# Altium schematic sheet 02-RCB_Header.SchDoc(02-RCB_Header)
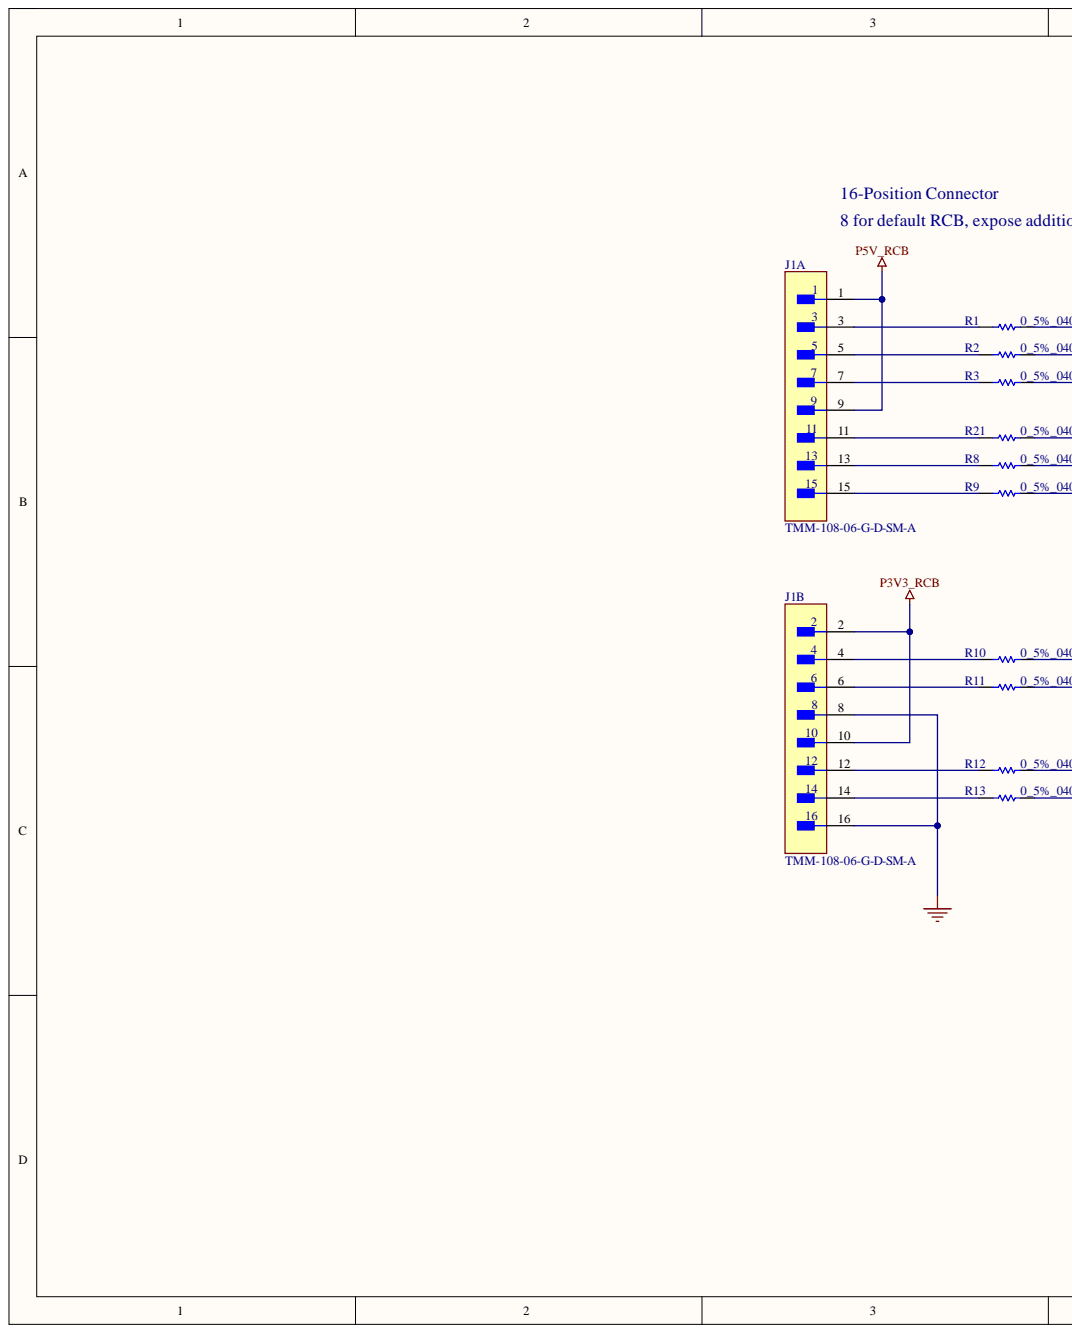
[diagram p0: 02-RCB_Header.SchDoc, left]
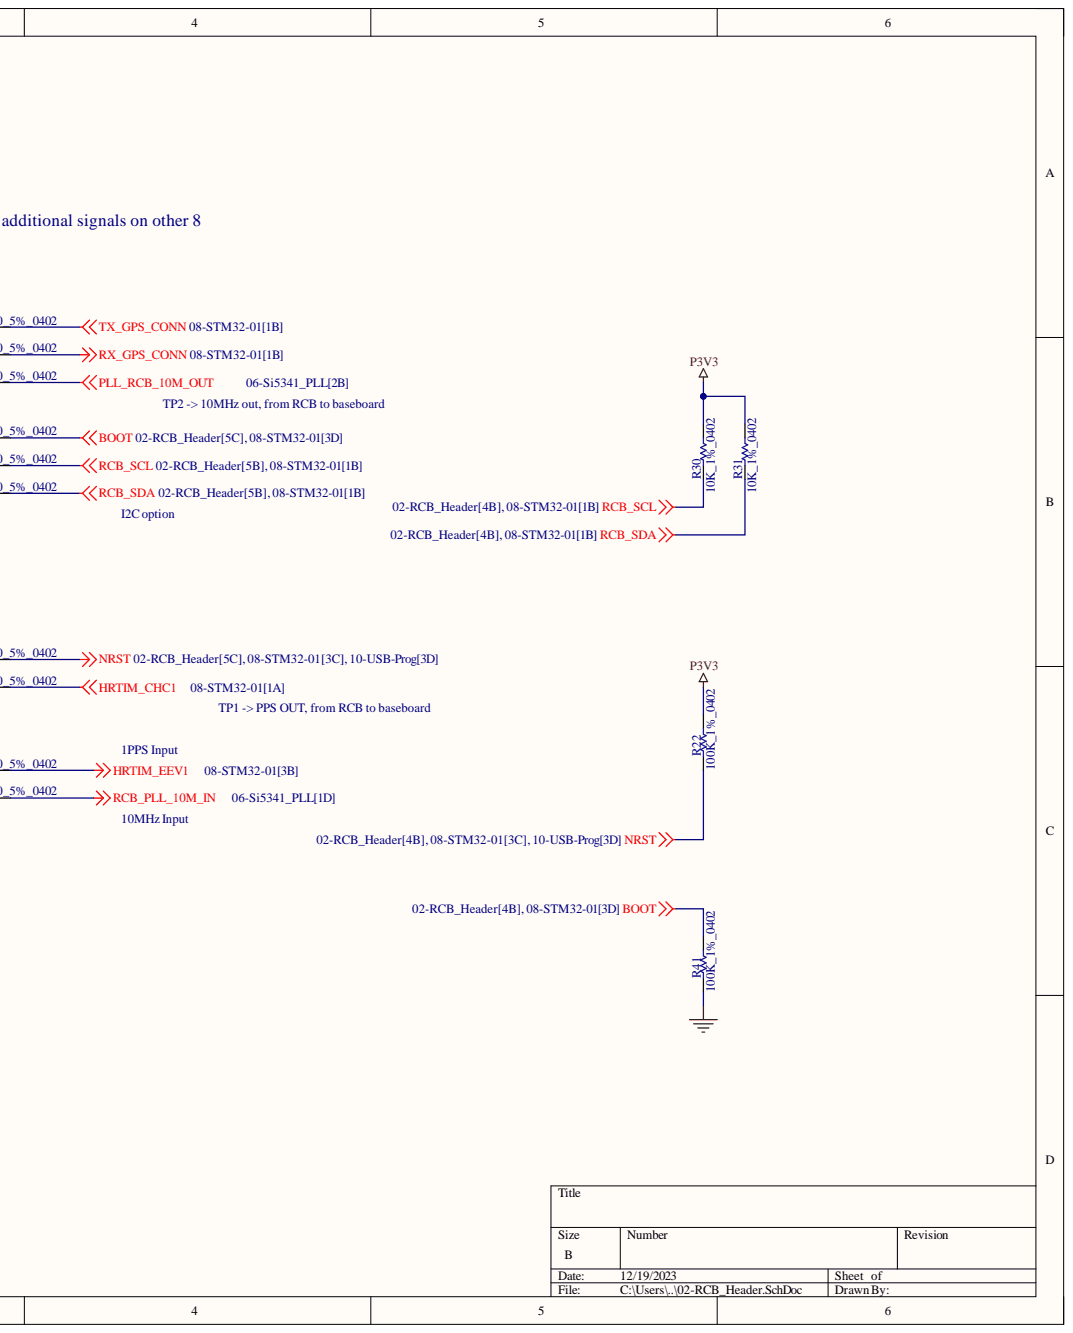
[diagram p1: 02-RCB_Header.SchDoc, right]

## Parts
J1  TMM-108-06-G-D-SM-A  [FP-TMM-108-06-G-D-SM-A-MFG]  (parts A,B)
R1  0_5%_0402  [RESC1005X40X25NL05T10]
R2  0_5%_0402  [RESC1005X40X25NL05T10]
R3  0_5%_0402  [RESC1005X40X25NL05T10]
R8  0_5%_0402  [RESC1005X40X25NL05T10]
R9  0_5%_0402  [RESC1005X40X25NL05T10]
R10  0_5%_0402  [RESC1005X40X25NL05T10]
R11  0_5%_0402  [RESC1005X40X25NL05T10]
R12  0_5%_0402  [RESC1005X40X25NL05T10]
R13  0_5%_0402  [RESC1005X40X25NL05T10]
R21  0_5%_0402  [RESC1005X40X25NL05T10]
R22  100K_1%_0402  [RESC1005X40X25ML05T10]
R30  10K_1%_0402  [RESC1005X40X25NL10T10]
R31  10K_1%_0402  [RESC1005X40X25NL10T10]
R41  100K_1%_0402  [RESC1005X40X25ML05T10]

## Nets
BOOT: R21.2 R41.2
GND: J1.8 J1.16 R41.1
HRTIM_CHC1: R11.2
HRTIM_EEV1: R12.2
NRST: R10.2 R22.1
P3V3: R22.2 R30.2 R31.2
P3V3_RCB: J1.2 J1.10
P5V_RCB: J1.1 J1.9
PLL_RCB_10M_OUT: R3.2
RCB_PLL_10M_IN: R13.2
RCB_SCL: R8.2 R30.1
RCB_SDA: R9.2 R31.1
RX_GPS_CONN: R2.2
TX_GPS_CONN: R1.2
NetJ1_3: J1.3 R1.1
NetJ1_4: J1.4 R10.1
NetJ1_5: J1.5 R2.1
NetJ1_6: J1.6 R11.1
NetJ1_7: J1.7 R3.1
NetJ1_11: J1.11 R21.1
NetJ1_12: J1.12 R12.1
NetJ1_13: J1.13 R8.1
NetJ1_14: J1.14 R13.1
NetJ1_15: J1.15 R9.1

## Library data of the parts
J1 = CMP-02766-001527-1: CONN HEADER SMD 16POS 2MM; Gender: Male; Max Current Rating: 3.9A; Max Voltage Rating (AC): 500V; Number of Contacts: 16; Component Kind: Standard; Contact Material: Bronze; Contact Plating: Gold; Depth: 3.94mm; Housing Color: Black; Housing Material: Polymer; Length: 16mm; Library Name: Altium Content Vault; Max Operating Temperature: 125°C; Min Operating Temperature: -55°C; Number of Rows: 2; Orientation: Straight; Packaging: Bulk; Pitch: 2mm; Radiation Hardening: No; RoHS Compliant: Yes; Termination: Solder
R1-R3 R8-R13 R21 = CMP-2002-06457-1: Manufacturer: Panasonic; Part Number: ERJ-U020R00X; Component Kind: Standard; Library Name: Altium Content Vault
R22 R41 = CMP-2002-07852-1: Manufacturer: Yageo; Part Number: RC0402FR-13100KL; Component Kind: Standard; Library Name: Altium Content Vault
R30 R31 = CMP-2002-04694-1: Manufacturer: Bourns; Part Number: CR0402-FX-1002GLF; Component Kind: Standard; Library Name: Altium Content Vault
